# Altium schematic sheet H01R0_Frontend 1.SchDoc("H01R0_Frontend 1")
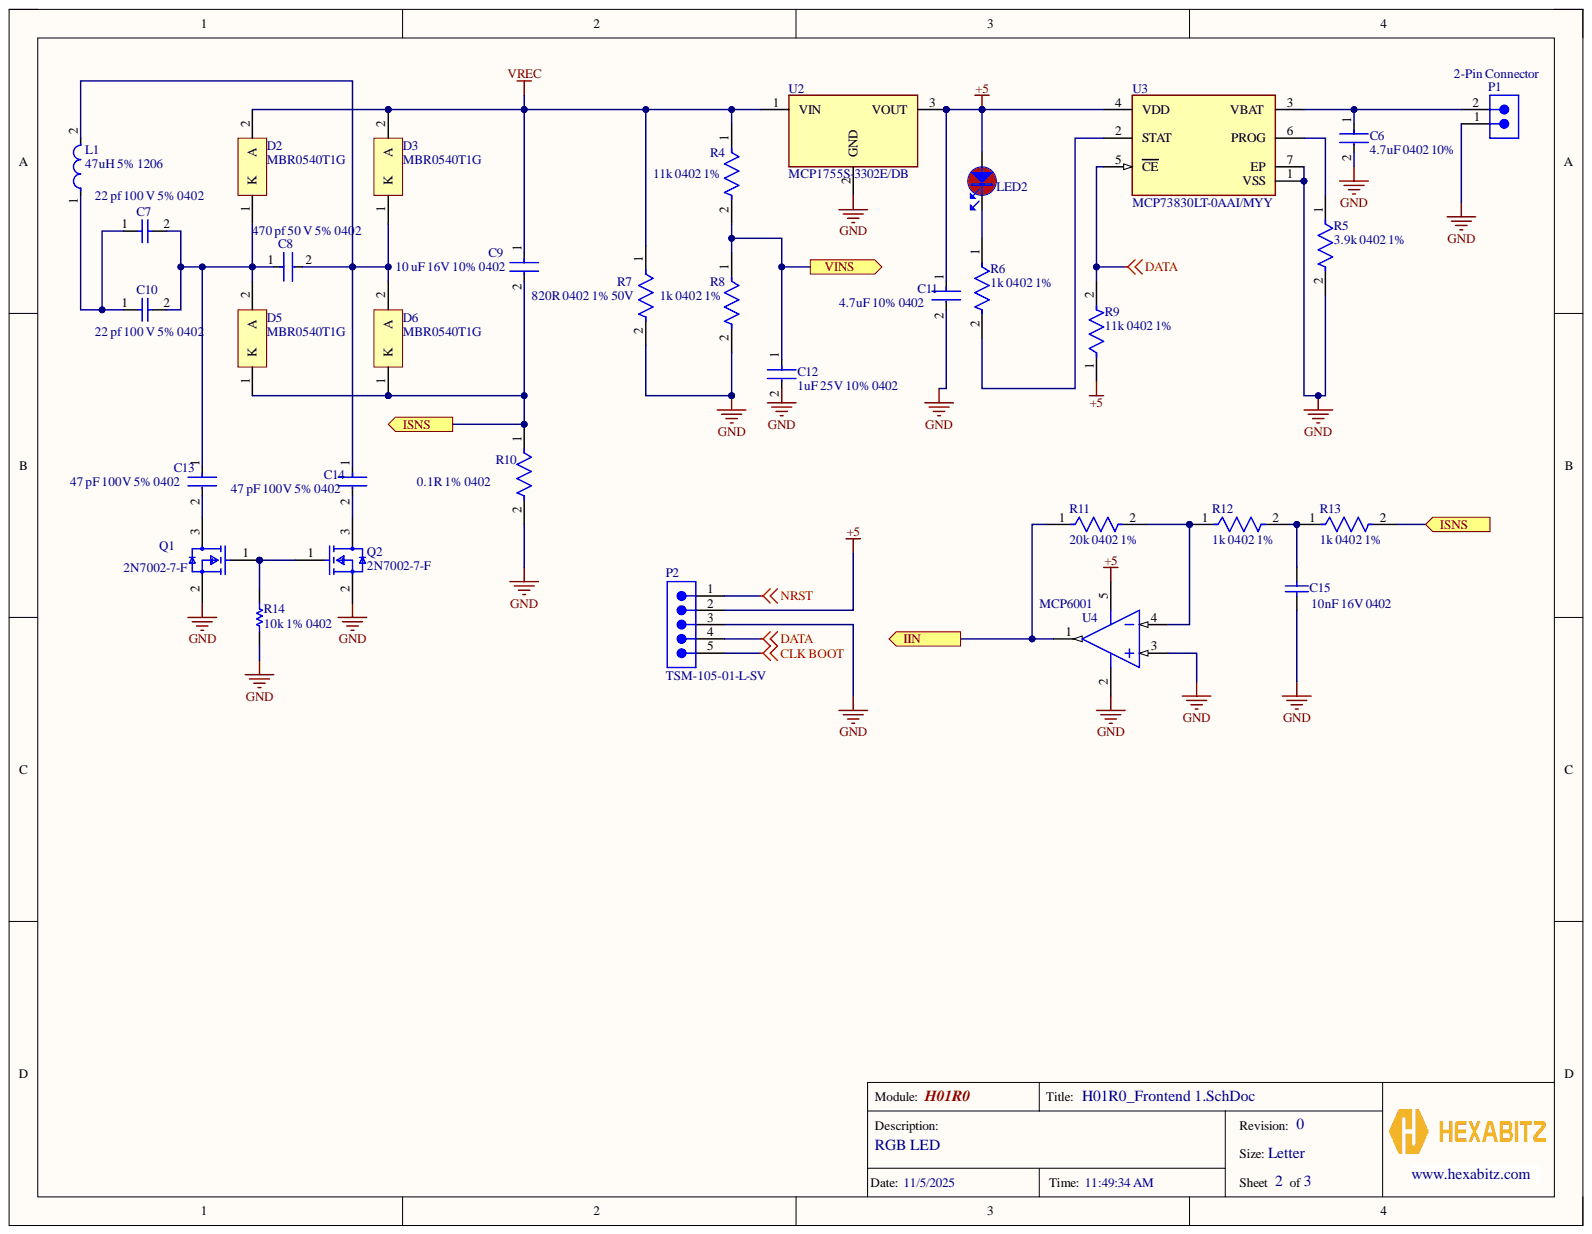
[diagram p0: H01R0_Frontend 1.SchDoc]

## Parts
C6  4.7uF 0402 10%  Capacitance: 4.7uF  [FP-GRM188-0_2-IPC_A]
C7  22 pf 100 V 5% 0402  [CAPC1005X61X26LL10T16]
C8  470 pf 50 V 5% 0402  Voltage Rating: 50 V  [FP-C0402-BB-MFG]
C9  10 uF 16V 10% 0402  [FP-GRM21B-0_1-IPC_B]
C10  22 pf 100 V 5% 0402  [CAPC1005X61X26LL10T16]
C11  4.7uF 10% 0402  [FP-GRM188-0_2-IPC_A]
C12  1uF 25V 10% 0402  Capacitance: 1uF  Voltage Rating (DC): 25V  [FP-GRM155-0_05-IPC_C]
C13  47 pF 100V 5% 0402  Case/Package: 0402  [FP-GQM155-0_05-IPC_A]
C14  47 pF 100V 5% 0402  Case/Package: 0402  [FP-GQM155-0_05-IPC_A]
C15  10nF 16V 0402  [CAPC1005X60X26LL10T16]
D2  MBR0540T1G  [ONSC-SOD-123-2-425-04_V]
D3  MBR0540T1G  [ONSC-SOD-123-2-425-04_V]
D5  MBR0540T1G  [ONSC-SOD-123-2-425-04_V]
D6  MBR0540T1G  [ONSC-SOD-123-2-425-04_V]
L1  47uH 5% 1206  Inductance: 47uH  [FP-LQH31CN_03-MFG]
LED2  VLMS1300-GS08  [FP-6_541-5092_01-4-MFG]  (value not drawn)
P1  2-Pin Connector  [679302124022]
P2  TSM-105-01-L-SV  [SMTC-TSM-105-01-X-SV]
Q1  2N7002-7-F  [FP-SOT23-MFG]
Q2  2N7002-7-F  [FP-SOT23-MFG]
R4  11k 0402 1%  Case/Package: 0402  Tolerance: 1%  [FP-CRCW0402-e3-IPC_B]
R5  3.9k 0402 1%  [FP-RN73R1E-IPC_C]
R6  1k 0402 1%  [FP-AC0402-IPC_C]
R7  820R 0402 1% 50V  Case/Package: 0402  [FP-MCS0402-IPC_C]
R8  1k 0402 1%  Tolerance: 1%  [FP-RNCP0402-IPC_C]
R9  11k 0402 1%  Case/Package: 0402  [FP-CRCW0402-e3-IPC_B]
R10  0.1R 1% 0402  [FP-CSR0402-IPC_A]
R11  20k 0402 1%  [FP-TFCR0402-IPC_A]
R12  1k 0402 1%  Tolerance: 1%  [FP-RNCP0402-IPC_C]
R13  1k 0402 1%  Tolerance: 1%  [FP-RNCP0402-IPC_C]
R14  10k 1% 0402  [RESC1005X40X25LL10T10]
U2  MCP1755S-3302E/DB  [FP-C04-032-MFG]
U3  MCP73830LT-0AAI/MYY  [FP-C04-078-IPC_C]
U4  MCP6001  [SOT-23-OT5_L]

## Ports
IIN, ISNS, VINS

## Nets
+5: C11.1 LED2.2 P2.2 R9.1 U2.3 U3.4(VDD) U4.5
CLK BOOT: P2.5
DATA: P2.4 R9.2 U3.5(CE)
GND: C6.2 C11.2 C12.2 C15.2 P1.1 P2.3 Q1.2 Q2.2 R5.2 R7.2 R8.2 R10.2 R14.1 U2.2 U3.1(VSS) U3.7(EP) U4.2 U4.3
NRST: P2.1
VREC: C9.1 D2.2 D3.2 R4.1 R7.1 U2.1
IIN: R11.1 U4.1 ; port IIN
ISNS: C9.2 D5.1 D6.1 R10.1 R13.2 ; port ISNS
VINS: C12.1 R4.2 R8.1 ; port VINS
NetC6_1: C6.1 P1.2 U3.3(VBAT)
NetC7_1: C7.1 C10.1 L1.1
NetC7_2: C7.2 C8.1 C10.2 C13.1 D2.1 D5.2
NetC8_2: C8.2 C14.1 D3.1 D6.2 L1.2
NetC13_2: C13.2 Q1.3
NetC14_2: C14.2 Q2.3
NetC15_1: C15.1 R12.2 R13.1
NetLED2_1: LED2.1 R6.1
NetQ1_1: Q1.1 Q2.1 R14.2
NetR5_1: R5.1 U3.6(PROG)
NetR6_2: R6.2 U3.2(STAT)
NetR11_2: R11.2 R12.1 U4.4

## Library data of the parts
C6 C11 = CMP-06035-002362-1: Chip Multilayer Ceramic Capacitors for General Purpose, 0603, 4.7uF, X7S, 22%, 10%, 16V; Component Kind: Standard; Dielectric: X7S; Length: 1.6mm; Library Name: Altium Content Vault; Thickness: 800um; Tolerance: 10%; Voltage Rating (DC): 16V; Width: 800um
  C11: Capacitance: 4.7uF
C7 C10 = CMP-2008-02000-1: Manufacturer: Vitramon; Part Number: VJ0402D220JXBAJ; Component Kind: Standard; Library Name: Altium Content Vault
C8 = CMP-03020-013778-2: C0402 470 pF C0G 0.05 50 V; Manufacturer: Kemet; Manufacturer Part Number: C0402C471J5GAC; Capacitance: 470 pF; Material: Ceramic; Tolerance: 0.05; Value: 470 pF; Automotive: No; Category: Cap; Component Kind: Standard; ComponentLink2Description: Datasheet; ComponentLink2URL: https://content.kemet.com/datasheets/KEM_C1002_X7R_SMD.pdf; Device Class L1: Passive Components; Device Class L2: Capacitors; Device Class L3: Ceramic Capacitors; Height: 0.55mm; Ipc Land Pattern Name: CAPC10050X50; Lead Free: Yes; Library Name: Altium Content Vault; Mouser Description: Cap Ceramic 470pF 50V C0G 5% Pad SMD 0402 125C Bulk; Octopart: https://octopart.com/c0402c471j5gac-kemet-29626191; Package: C0402-BB; RoHS: TRUE; Temperature: -55°C to +125°C; Temperature Characteristic: C0G; Temperature Range High: +125°C; Temperature Range Low: -55°C
C9 = CMP-2007-03503-2: Chip Multilayer Ceramic Capacitors for General Purpose, 0805, 10uF, X6S, 22%, 10%, 16V; Capacitance: 10uF; Case Code (Imperial): 0805; Case Code (Metric): 2012; Case/Package: 0805; Component Kind: Standard; Depth: 1.25mm; Dielectric: X6S; Height: 1.25mm; Lead Free: Lead Free; Length: 2mm; Library Name: Altium Content Vault; Max Operating Temperature: 105°C; Min Operating Temperature: -55°C; Mount: Surface Mount; Package Quantity: 3000; Packaging: Tape and Reel; REACH SVHC: No SVHC; Termination: SMD/SMT; Thickness: 0.053inch; Tolerance: 10%; Voltage: 16V; Voltage Rating: 16V; Voltage Rating (DC): 16V; Weight: 0.000335oz; Width: 0.049inch
C12 = CMP-06035-057649-1: Chip Multilayer Ceramic Capacitors for General Purpose, 0402, 1.0uF, B, 10%, 10%, 25V; Component Kind: Standard; Length: 1mm; Library Name: Altium Content Vault; Thickness: 500um; Tolerance: 10%; Width: 500um
C13 C14 = CMP-06035-010628-1: Ceramic Capacitor 47pF  ±5% 100VDC C0G 0402 Paper T/R; Capacitance: 47pF; Component Kind: Standard; Dielectric: C0G; Length: 0.039inch; Library Name: Altium Content Vault; Max Operating Temperature: 125°C; Min Operating Temperature: -55°C; Mount: Surface Mount; Packaging: Tape and Reel; Thickness: 0.022inch; Tolerance: 5%; Voltage Rating: 100V; Voltage Rating (DC): 100V; Width: 0.02inch
C15 = CMP-2008-02025-1: Manufacturer: Vitramon; Part Number: VJ0402Y103KXJAC; Component Kind: Standard; Library Name: Altium Content Vault
D2 D3 D5 D6 = CMP-1055-00401-1: Schottky Power Rectifier, 2-Pin SOD-123, Pb-Free, Tape and Reel; Manufacturer: On Semiconductor; Configuration: Single; IFSM Max (A): 5.5; Component Kind: Standard; ComponentLink1Description: Manufacturer URL; ComponentLink1URL: http://www.onsemi.com/; ComponentLink2Description: Datasheet; ComponentLink2URL: http://www.onsemi.cn/pub_link/Collateral/MBR0540T1-D.PDF; ComponentLink3Description: Package Specification; ComponentLink3URL: http://www.onsemi.com/pub_link/Collateral/425-04.PDF; DatasheetVersion: Rev. 7; Library Name: Altium Content Vault; Mounting Technology: Surface Mount; PackageDescription: 2-Pin SOD, Body 1.6 x 2.69 mm; PackageReference: SOD-123-2-425-04; PackageVersion: Rev. G, 10/2009; Packing: Tape and Reel; PartNumber: MBR0540T1G; RoHS: TRUE
L1 = CMP-06042-003344-1: Wire Wound Ferrite Inductor for General Circuits 47μH ±5% 10.4Ω 55mA 1206; DC Resistance (DCR): 8R; Q Factor: 40; Self Resonant Frequency: 10MHz; Shielding: Unshielded; Test Frequency: 1MHz; Case Code (Imperial): 1206; Case Code (Metric): 3216; Case/Package: 1206; Component Kind: Standard; Core Material: Ferrite; Current Rating: 55mA; DC Current: 55mA; Depth: 1.8mm; Height: 1.8mm; Height - Seated (Max): 0.079inch; Length: 0.126inch; Library Name: Altium Content Vault; Max DC Current: 55mA; Max Operating Temperature: 85°C; Military Standard: Not; Min Operating Temperature: -40°C; Mount: Surface Mount; Package Quantity: 2000; Packaging: Tape and Reel; Radiation Hardening: No; Resistor Type: Wirewound; Termination: SMD/SMT; Tolerance: 5%; Weight: 0.001023oz; Width: 0.063inch
LED2 = VLMS1300-GS08: LED Uni-Color Super Red 639nm 2-Pin Chip 0603(1608Metric) T/R; Manufacturer: Vishay Semiconductors; Dominant Wavelength: 631nm; Forward Current: 20mA; Forward Voltage: 2V; Lens Style: Rectangular,Clear; Library: Hexabitz; Luminous Intensity: 54mcd; Number of LEDs: 1; Power Rating: 75mW; Viewing Angle: 130°; Case Code (Imperial): 0603; Case Code (Metric): 1608; Case/Package: 0603; Category: LEDs; Color: Red; Component Kind: Standard; ComponentLink1Description: https://datasheet.ciiva.com/26322/vlmo1300-26322153.pdf?src-supplier=Element14; ComponentLink1URL: https://datasheet.ciiva.com/26322/vlmo1300-26322153.pdf?src-supplier=Element14; ComponentLink2Description: https://datasheet.ciiva.com/8028/vlmo1300-8028047.pdf?src-supplier=Element14; ComponentLink2URL: https://datasheet.ciiva.com/8028/vlmo1300-8028047.pdf?src-supplier=Element14; ComponentLink3Description: https://datasheet.ciiva.com/9333/vlmo1300-9333743.pdf?src-supplier=Avnet; ComponentLink3URL: https://datasheet.ciiva.com/9333/vlmo1300-9333743.pdf?src-supplier=Avnet; ComponentLink4Description: https://datasheet.ciiva.com/16510/vlmo1300-16510985.pdf?src-supplier=Future+Electronics; ComponentLink4URL: https://datasheet.ciiva.com/16510/vlmo1300-16510985.pdf?src-supplier=Future+Electronics; ComponentLink5Description: https://datasheet.ciiva.com/2114/vlmo1300-2114930.pdf?src-supplier=Digikey; ComponentLink5URL: https://datasheet.ciiva.com/2114/vlmo1300-2114930.pdf?src-supplier=Digikey; ComponentLink6Description: https://datasheet.ciiva.com/2114/vlmo1300-2114931.pdf?src-supplier=Digikey; ComponentLink6URL: https://datasheet.ciiva.com/2114/vlmo1300-2114931.pdf?src-supplier=Digikey; ComponentLink7Description: https://datasheet.ciiva.com/2114/vlmo1300-2114929.pdf?src-supplier=Digikey; ComponentLink7URL: https://datasheet.ciiva.com/2114/vlmo1300-2114929.pdf?src-supplier=Digikey; Height: 0.8mm; Illumination Color: Red; Lead Free: Lead Free; Length: 1.6mm; Library Name: Hexabitz Altium Library.IntLib; Manufacturer Part Number: VLMS1300-GS08; Max Operating Temperature: 85°C; Min Operating Temperature: -35°C; Mounting Technology: Surface Mount; Package Quantity: 1; Packaging: Tape and Reel; Pricing: 1=0.21, 10=0.143, 100=0.0998, 500=0.07982, 1000=0.07322 (USD); Radiation Hardening: No; Reach SVHC Compliant: Unknown; RoHS: Yes; RoHS Compliant: Yes; Series: CHipLED; Stock: 56745; Supplier: Digikey; Supplier 1: Digikey; Supplier Part Number: VLMS1300-GS08DKR-ND; Supplier Part Number 1: VLMS1300-GS08DKR-ND; Test Current: 20mA; Wavelength: 631nm; Width: 0.8mm
P1 = CMP-1502-00721-2: NexarPartId: 18818281; Connector Type: Male Pin; Contact Resistance: 20mΩ; Current Rating: 1A; Gender: Male; Insulation: Insulated; Insulation Resistance: 100MΩ; Number of Contacts: 2; Operating Supply Voltage: 50V; Pitch: 1.5mm; Color: Beige, White; Component Kind: Standard; Contact Gender: Male; Contact Material: Copper; Contact Plating: Tin; Flammability Rating: UL94 V-0; Height: 5mm; Housing Material: Nylon; Lead Pitch: 1.5mm; Length: 6mm; Library Name: Altium Content Vault; Max Operating Temperature: 85°C; Min Operating Temperature: -25°C; Mount: Surface Mount; Number of Pins: 2; Number of Positions: 2; Number of Rows: 1; Orientation: Vertical; Packaging: Tape and Reel; REACH SVHC: Unknown; RoHS: Compliant; Termination: SMD/SMT; Voltage Rating: 50V; Width: 6mm
P2 = CMP-1024-00241-1: 0.025" SQ Post Header, Surface Mount, Vertical, -55 to 125 degC, 2.54 mm Pitch, 5-Pin, Male, RoHS; Manufacturer: Samtec; Pitch: 2.54 mm; Component Kind: Standard; ComponentLink1Description: Manufacturer URL; ComponentLink1URL: http://www.samtec.com; ComponentLink2Description: Datasheet; ComponentLink2URL: http://www.samtec.com/documents/webfiles/pdf/TSM.PDF; ComponentLink3Description: Package Specification; ComponentLink3URL: http://www.samtec.com/documents/webfiles/cpdf/TSM-1XX-XX-XXX-SV-XX-XXX-XX-MKT.pdf; DatasheetVersion: F-214; Library Name: Altium Content Vault; Mounting Technology: Surface Mount; Orientation: Vertical; PackageDescription: Single Row .100 SMT Assembly; PackageReference: TSM-105-01-X-SV; PackageVersion: BP, 8/2002; PartNumber: TSM-105-01-L-SV; RoHS: True; Temperature Range: -55 to 125 degC
Q1 Q2 = CMP-2000-04929-2: MOSFET N-CH 60V 115MA SOT23-3; Drain to Source Breakdown Voltage: 70V; Drain to Source Resistance: 7.5R; Element Configuration: Single; Fall Time: 5.6ns; Gate to Source Voltage (Vgs): 20V; Input Capacitance: 50pF; Max Power Dissipation: 300mW; Nominal Vgs: 2.5V; Number of Channels: 1; Power Dissipation: 540mW; Rise Time: 3ns; Turn-Off Delay Time: 11ns; Turn-On Delay Time: 7ns; Case/Package: SOT-23; Component Kind: Standard; Contact Plating: Tin; Continuous Drain Current (ID): 115mA; Current Rating: 115mA; Drain to Source Voltage (Vdss): 60V; Dual Supply Voltage: 60V; Height: 1mm; Lead Free: Lead Free; Length: 2.9mm; Library Name: Altium Content Vault; Max Operating Temperature: 150°C; Min Operating Temperature: -55°C; Mount: Surface Mount; Number of Elements: 1; Number of Pins: 3; On-State Resistance: 7.5R; Package Quantity: 3000; Packaging: Tape and Reel; Radiation Hardening: No; Rds On Max: 7.5R; REACH SVHC: No SVHC; Termination: SMD/SMT; Threshold Voltage: 2.5V; Voltage: 60V; Voltage Rating (DC): 60V; Weight: 0.000282oz; Width: 1.3mm
R4 R9 = CMP-02407-002513-1: RES Thick Film, 11kΩ, 1%, 0.063W, 100ppm/°C, 0402; Max Power Dissipation: 63mW; Resistance: 11kR; Component Kind: Standard; Composition: Thick Film; Features: Automotive AEC-Q200; Height: 0.016inch; Lead Free: Lead Free; Length: 0.039inch; Library Name: Altium Content Vault; Max Operating Temperature: 155°C; Min Operating Temperature: -55°C; Number of Terminations: 2; Package Quantity: 50000; Packaging: Tape and Reel; Temperature Coefficient: 100ppm/°C; Width: 0.02inch
  R9: Tolerance: 1%
R5 = CMP-04015-061446-1: 3.9kΩ ±1% 0.063W, 1/16W Chip Resistor 0402 (1005 Metric) Moisture Resistant Thin Film; Component Kind: Standard; Library Name: Altium Content Vault
R6 = CMP-03412-047873-1: RES 1kΩ ±1% 0.063W 0402; Component Kind: Standard; Library Name: Altium Content Vault; RoHS Compliant: Yes
R7 = CMP-02407-004026-1: RES SMD 1.2K OHM 1% 1/10W 0402; Max Power Dissipation: 100mW; Temperature Coefficient: 50ppm/°C; Component Kind: Standard; Composition: Thin Film; Contact Plating: Tin; Depth: 0.5mm; Height: 0.015inch; Lead Free: Lead Free; Length: 0.039inch; Library Name: Altium Content Vault; Max Operating Temperature: 125°C; Military Standard: Not; Min Operating Temperature: -55°C; Number of Terminations: 2; Package Quantity: 10000; Packaging: Tape and Reel; Radiation Hardening: No; Resistance: 820R; Tolerance: 1%; Width: 0.02inch
R8 R12 R13 = CMP-26527-002183-1: 1kΩ ±1% 0.1W 0402 High Power Anti-Sulfur Thin Film Chip Resistor; Component Kind: Standard; Library Name: Altium Content Vault; Max Operating Temperature: 155°C; Min Operating Temperature: -55°C; Mount: Surface Mount
R10 = CMP-26527-000729-1: General Purpose Thick Film Chip Resistor, 0402, 0.1Ω, 1%, 200ppm/°C, 0.125W; Max Power Dissipation: 125mW; Resistance: 100mR; Case/Package: 0402; Component Kind: Standard; Contact Plating: Tin; Height: 0.017inch; Length: 0.039inch; Library Name: Altium Content Vault; Max Operating Temperature: 155°C; Min Operating Temperature: -55°C; Mount: Surface Mount; Number of Terminations: 2; Package Quantity: 10000; Packaging: Tape and Reel; Radiation Hardening: No; Resistor Type: Thick Film; RoHS Compliant: Yes; Series: CSR; Temperature Coefficient: 200ppm/°C; Tolerance: 1%; Width: 0.02inch
R11 = CMP-250906-149647-1: RES Thin Film,  20kΩ, 1%, 0.063W, 50ppm/°C, 0402; Component Kind: Standard; Library Name: Altium Content Vault
R14 = CMP-2002-04584-1: Manufacturer: KOA Speer; Part Number: SG73P1ETTP1002F; Component Kind: Standard; Library Name: Altium Content Vault
U2 = CMP-06324-000158-1: IC REG LIN 3.3V 300MA SOT223-3; Accuracy: 2%; Dropout Voltage: 300mV; Max Input Voltage: 16V; Max Supply Current: 400uA; Min Input Voltage: 3.6V; Nominal Supply Current: 68uA; Number of Outputs: 1; Output Configuration: Positive; Output Type: Fixed; Power Supply Rejection Ratio (PSRR): 80dB; Quiescent Current: 100uA; Case/Package: SOT-223-3; Component Kind: Standard; Height: 1.7mm; Lead Free: Lead Free; Length: 6.7mm; Library Name: Altium Content Vault; Max Operating Temperature: 125°C; Max Output Current: 300mA; Min Operating Temperature: -40°C; Mount: Surface Mount; Nominal Output Voltage: 3.3V; Number of Pins: 3; Number of Regulators: 1; Output Current: 300mA; Output Voltage: 3.3V; Package Quantity: 78; Packaging: Rail/Tube; Polarity: Positive; Reach SVHC Compliant: Yes; RoHS Compliant: Yes; Series: -; Supply Current: 68uA; Tolerance: 2%; Weight: 0.008826oz; Width: 3.7mm
U3 = CMP-06318-000013-1: IC BATT CHG LI-ION 1CELL 6TDFN; Max Supply Voltage: 6V; Min Supply Voltage: 3.75V; Component Kind: Standard; Lead Free: Lead Free; Library Name: Altium Content Vault; Max Operating Temperature: 125°C; Min Operating Temperature: -40°C; Mount: Surface Mount; Number of Pins: 6; Package Quantity: 3000; Packaging: Tape and Reel; Radiation Hardening: No; RoHS Compliant: Yes
U4 = CMP-0187-00458-3: None; Amplifier Type: GENERAL PURPOSE; Bandwidth: 1MHz; Common Mode Rejection Ratio: 60dB; Input Bias Current: 1pA; Input Offset Voltage (Vos): 4.5mV; Max Supply Voltage: 6V; Min Supply Voltage: 1.8V; Nominal Supply Current: 100uA; Number of Amplifiers: 1; Operating Supply Voltage: 5.5V; Output Current: 23mA; Power Supply Rejection Ratio (PSRR): 86dB; Slew Rate: 0.6V/µs; Voltage Gain: 112dB; Case/Package: SOT-23; Component Kind: Standard; Contact Plating: Tin; Gain Bandwidth Product: 1MHz; Height: 1.3mm; Input Offset Voltage-Max: 4.5mV; Lead Free: Lead Free; Length: 3.1mm; Library Name: Altium Content Vault; Max Operating Temperature: 125°C; Min Operating Temperature: -40°C; Mount: Surface Mount; Number of Channels: 1; Number of Circuits: 1; Number of Elements: 1; Number of Pins: 5; Operating Supply Current: 100uA; Output Current per Channel: 23mA; Package Quantity: 3000; Packaging: Tape and Reel; Radiation Hardening: No; REACH SVHC: No SVHC; RoHS Compliant: Yes; Slew Rate-Nom: 0.6V/µs; Supply Current: 100uA; Technology: CMOS; Unity Gain Bandwidth Product: 1MHz; Voltage: 6V; Width: 1.8mm
